# Altium schematic sheet ESP32_RC_MOD_V1.SchDoc
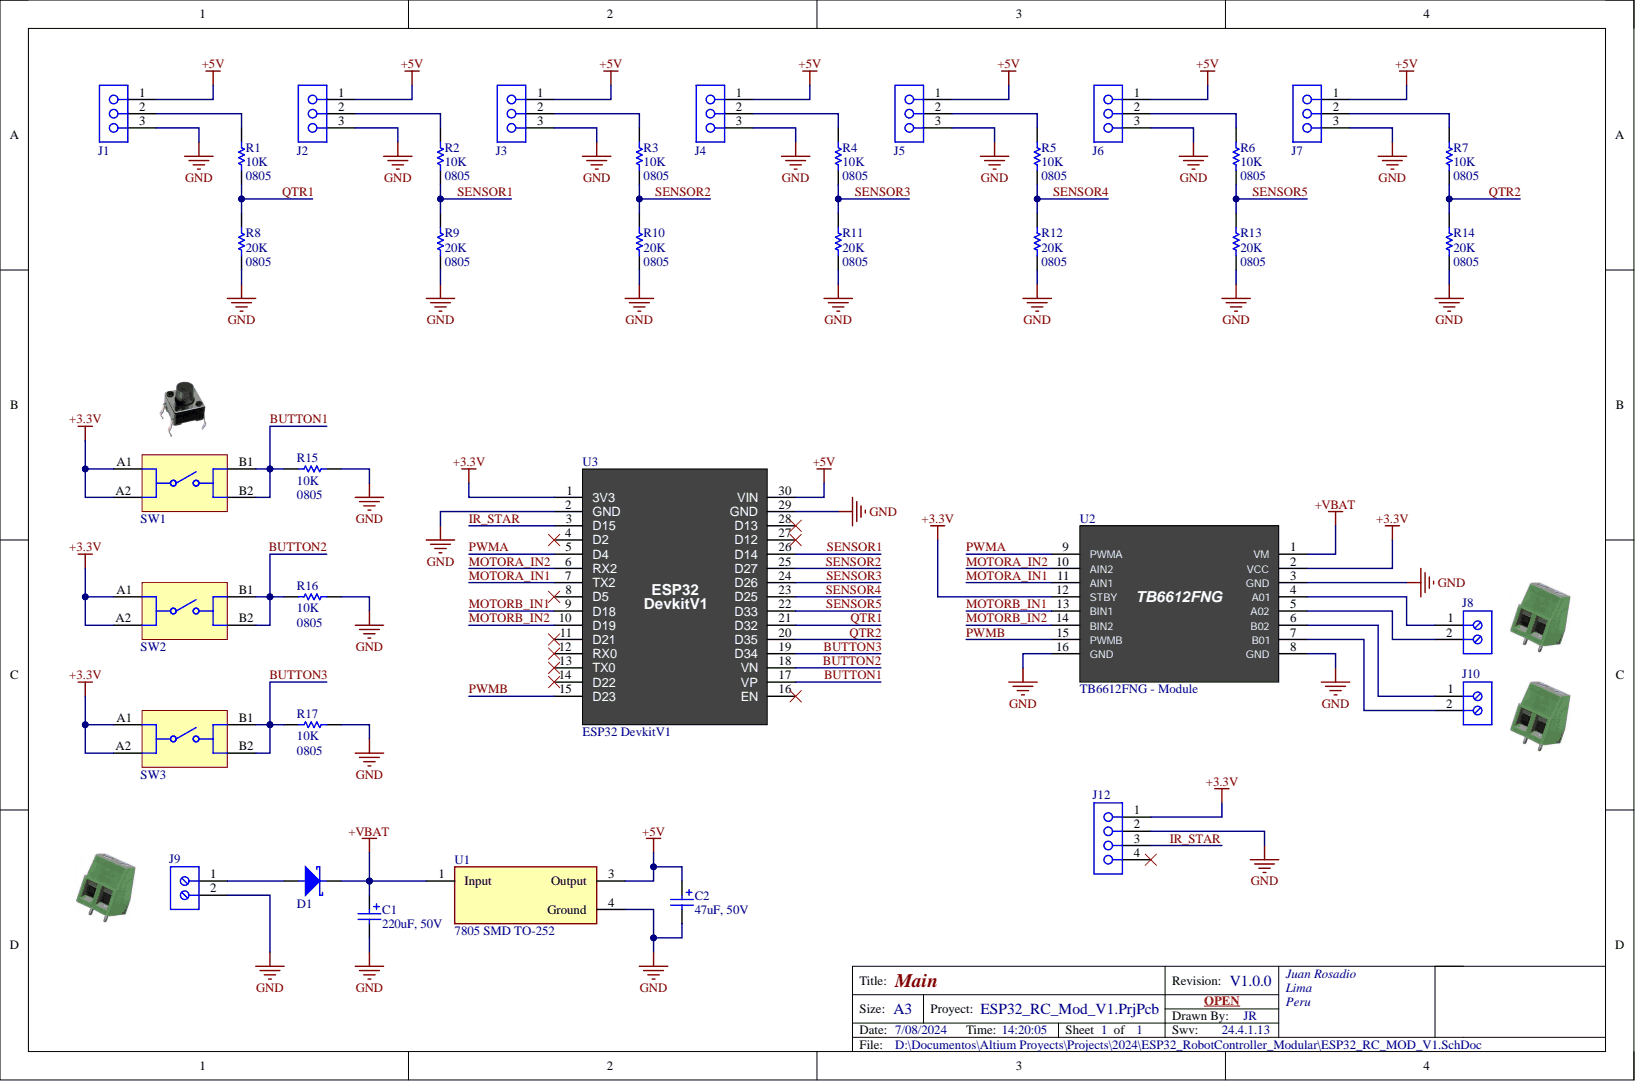
[diagram p0: ESP32_RC_MOD_V1.SchDoc]

## Parts
C1  220uF, 50V  [WCAP-ATG8_D10H12.5]
C2  47uF, 50V  [WCAP-ATG8_D6.3H11]
D1  Diode Schottky  [DO-214AB]  (value not drawn)
J1  Header 3P  [61300311821]  (value not drawn)
J2  Header 3P  [61300311821]  (value not drawn)
J3  Header 3P  [61300311821]  (value not drawn)
J4  Header 3P  [61300311821]  (value not drawn)
J5  Header 3P  [61300311821]  (value not drawn)
J6  Header 3P  [61300311821]  (value not drawn)
J7  Header 3P  [61300311821]  (value not drawn)
J8  Bornera de 2 pines  [691111710002]  (value not drawn)
J9  Bornera de 2 pines  [691111710002]  (value not drawn)
J10  Bornera de 2 pines  [691111710002]  (value not drawn)
J12  Header 4p  [61300411821]  (value not drawn)
R1  Case/Package: 0805  Resistance: 10K  [RES0805A]  (hidden comment: Resistor 0805)
R2  Case/Package: 0805  Resistance: 10K  [RES0805A]  (hidden comment: Resistor 0805)
R3  Case/Package: 0805  Resistance: 10K  [RES0805A]  (hidden comment: Resistor 0805)
R4  Case/Package: 0805  Resistance: 10K  [RES0805A]  (hidden comment: Resistor 0805)
R5  Case/Package: 0805  Resistance: 10K  [RES0805A]  (hidden comment: Resistor 0805)
R6  Case/Package: 0805  Resistance: 10K  [RES0805A]  (hidden comment: Resistor 0805)
R7  Case/Package: 0805  Resistance: 10K  [RES0805A]  (hidden comment: Resistor 0805)
R8  Case/Package: 0805  Resistance: 20K  [RES0805A]  (hidden comment: Resistor 0805)
R9  Case/Package: 0805  Resistance: 20K  [RES0805A]  (hidden comment: Resistor 0805)
R10  Case/Package: 0805  Resistance: 20K  [RES0805A]  (hidden comment: Resistor 0805)
R11  Case/Package: 0805  Resistance: 20K  [RES0805A]  (hidden comment: Resistor 0805)
R12  Case/Package: 0805  Resistance: 20K  [RES0805A]  (hidden comment: Resistor 0805)
R13  Case/Package: 0805  Resistance: 20K  [RES0805A]  (hidden comment: Resistor 0805)
R14  Case/Package: 0805  Resistance: 20K  [RES0805A]  (hidden comment: Resistor 0805)
R15  Case/Package: 0805  Resistance: 10K  [RES0805A]  (hidden comment: Resistor 0805)
R16  Case/Package: 0805  Resistance: 10K  [RES0805A]  (hidden comment: Resistor 0805)
R17  Case/Package: 0805  Resistance: 10K  [RES0805A]  (hidden comment: Resistor 0805)
SW1  XUNPU TS-1002S-07026C  [4301x60707x6]  (value not drawn)
SW2  XUNPU TS-1002S-07026C  [4301x60707x6]  (value not drawn)
SW3  XUNPU TS-1002S-07026C  [4301x60707x6]  (value not drawn)
U1  7805 SMD TO-252  [FP-369C-MFG]
U2  TB6612FNG - Module  [TB6612FNG-HDR-3D]
U3  ESP32 DevkitV1  [ESP32 DEVKITV1 - HDR - 3D]

## Nets
+3.3V: J12.1 SW1.A1 SW1.A2 SW2.A1 SW2.A2 SW3.A1 SW3.A2 U2.2(VCC) U2.12(STBY) U3.1(3V3)
+5V: C2.1 J1.1 J2.1 J3.1 J4.1 J5.1 J6.1 J7.1 U1.3 U3.30(VIN)
+VBAT: C1.1 D1.2 U1.1 U2.1(VM)
GND: C1.2 C2.2 J1.3 J2.3 J3.3 J4.3 J5.3 J6.3 J7.3 J9.2 J12.2 R8.1 R9.1 R10.1 R11.1 R12.1 R13.1 R14.1 R15.1 R16.1 R17.1 U1.4 U2.3(GND) U2.8(GND) U2.16(GND) U3.2(GND) U3.29(GND)
BUTTON1: R15.2 SW1.B1 SW1.B2 U3.17(VP)
BUTTON2: R16.2 SW2.B1 SW2.B2 U3.18(VN)
BUTTON3: R17.2 SW3.B1 SW3.B2 U3.19(D34)
IR_STAR: J12.3 U3.3(D15)
MOTORA_IN1: U2.11(AIN1) U3.7(TX2)
MOTORA_IN2: U2.10(AIN2) U3.6(RX2)
MOTORB_IN1: U2.13(BIN1) U3.9(D18)
MOTORB_IN2: U2.14(BIN2) U3.10(D19)
PWMA: U2.9(PWMA) U3.5(D4)
PWMB: U2.15(PWMB) U3.15(D23)
QTR1: R1.1 R8.2 U3.21(D32)
QTR2: R7.1 R14.2 U3.20(D35)
SENSOR1: R2.1 R9.2 U3.26(D14)
SENSOR2: R3.1 R10.2 U3.25(D27)
SENSOR3: R4.1 R11.2 U3.24(D26)
SENSOR4: R5.1 R12.2 U3.23(D25)
SENSOR5: R6.1 R13.2 U3.22(D33)
NetD1_1: D1.1 J9.1
NetJ1_2: J1.2 R1.2
NetJ2_2: J2.2 R2.2
NetJ3_2: J3.2 R3.2
NetJ4_2: J4.2 R4.2
NetJ5_2: J5.2 R5.2
NetJ6_2: J6.2 R6.2
NetJ7_2: J7.2 R7.2
NetJ8_1: J8.1 U2.4(A01)
NetJ8_2: J8.2 U2.5(A02)
NetJ10_1: J10.1 U2.6(B02)
NetJ10_2: J10.2 U2.7(B01)

Not connected: J12 4; U3 4(D2) 8(D5) 11(D21) 12(RX0) 13(TX0) 14(D22) 16(EN) 27(D12) 28(D13)

## Library data of the parts
C1 = 860010675018: WCAP-ATG8 Aluminum Electrolytic Capacitor, Radial, THT, D10xH12.5, 220uF, 50V; Manufacturer: Wurth Elektronik; Capacitance Tolerance: +/-20%; Dissipation Factor: 10%; Endurance: 2000h; Leakage Current: 110uA; Rated Voltage: 50VDC; Ripple Current: 468mA; Technical Reference: ATBF125221M050DSPA9B000; Capacitance: 220uF; Component Kind: Standard; ComponentLink1Description: Manufacturer; ComponentLink1URL: http://www.we-online.de; ComponentLink2Description: Datasheet; ComponentLink2URL: https://www.we-online.com/catalog/datasheet/860010675018.pdf; Diameter: 10mm; Height: 12.5mm; Manufacturer Part Number: 860010675018; Mounting Technology: THT; Operating Temperature: -40 to +85degC; Packaging: Ammo Pack; Published Date: October 2020; Library Name: WCAP-ATG8 Aluminion Electrolytic Capacitors.IntLib
C2 = 860010673012: WCAP-ATG8 Aluminum Electrolytic Capacitor, Radial, THT, D6.3xH11, 47uF, 50V; Manufacturer: Wurth Elektronik; Capacitance Tolerance: +/-20%; Dissipation Factor: 10%; Endurance: 2000h; Leakage Current: 23.5uA; Rated Voltage: 50VDC; Ripple Current: 165mA; Technical Reference: ATBD110470M050DSPA4B000; Capacitance: 47uF; Component Kind: Standard; ComponentLink1Description: Manufacturer; ComponentLink1URL: http://www.we-online.de; ComponentLink2Description: Datasheet; ComponentLink2URL: https://www.we-online.com/catalog/datasheet/860010673012.pdf; Diameter: 6.3mm; Height: 11mm; Manufacturer Part Number: 860010673012; Mounting Technology: THT; Operating Temperature: -40 to +85degC; Packaging: Ammo Pack; Published Date: October 2020; Library Name: WCAP-ATG8 Aluminion Electrolytic Capacitors.IntLib
D1 = Diode Schottky: Diodo Schottky SS36 3A 60 V; Forward Current: 4A; Voltage Rating (DC): 40V; Category: Rectifier Diodes; Component Kind: Standard; Library Name: Diodos.IntLib
J1-J7 = 61300311821: WR-PHD Socket Header, THT, Vertical, pitch 2.54mm, 1 Row, 3P; Manufacturer: Wurth Elektronik; Contact Resistance: 20mOhm; Rated Current: 3A; Withstanding Voltage: 500V; Working Voltage: 250V; Category: Board-to-Board Connectors; Component Kind: Standard; ComponentLink1Description: Website Link; ComponentLink1URL: https://www.we-online.com/redexpert/article/61300311821?ad; ComponentLink2Description: Datasheet Link; ComponentLink2URL: https://www.we-online.com/redexpert/spec/61300311821?ad; Gender: Socket Header; Library Name: WR-PHD (rev24a).IntLib; Manufacturer Part Number: 61300311821; Match Code: WR-PHD; Mount: Through Hole; Number of Pins: 3; Number of Rows: 1; Operating Temperature Max: 125°C; Operating Temperature Min: -40°C; Orientation: Vertical; Packaging: Tray; Pitch: 2.54mm
J8-J10 = 691111710002: WR-TBL Serie 111 Horizontal Entry Modular, Pressure Clamp, THT, pitch 5mm, 2p; Manufacturer: Wurth Elektronik; Modularity: Yes; PCB/Cable/Panel: PCB; Pin Number: 2; Rated Current [UL]: 15A; Working Voltage [UL]: 250VAC; Application: Pressure Clamp; Component Kind: Standard; ComponentLink1Description: Manufacturer; ComponentLink1URL: http://www.we-online.de; ComponentLink2Description: Datasheet; ComponentLink2URL: https://katalog.we-online.de/em/datasheet/691111710002.pdf; Manufacturer Part Number: 691111710002; Mounting Technology: THT; Operating Temperature: -40 to +105degC; Packaging: Box; Pitch: 5mm; Published Date: Feburary 2021; Type: Horizontal; Library Name: WR-TBL Terminal Blocks.IntLib
J12 = 61300411821: WR-PHD Socket Header, THT, pitch 2.54mm, Single Row, Vertical, 4p; Manufacturer: Wurth Elektronik; Contact Resistance: 20mOhm; Pin Number: 4; Rated Current: 3A; Withstanding Voltage: 500VAC; Working Voltage: 250VAC; Component Kind: Standard; ComponentLink1Description: Manufacturer; ComponentLink1URL: http://www.we-online.de; ComponentLink2Description: Datasheet; ComponentLink2URL: https://www.we-online.com/catalog/datasheet/61300411821.pdf; Gender: Socket Header; Manufacturer Part Number: 61300411821; Mounting Technology: THT; Operating Temperature: -40 to +125degC; Orientation: Vertical; Packaging: Tray; Pitch: 2.54mm; Published Date: May 2020; Row Number: Single; Library Name: WE-WR PHD Conectors.IntLib
R1-R7 R15-R17 = Resistor 0805: SMD 0805 10Kohms; Power Rating: 125mW; Tolerance: 1%; Case Code (Metric): 2012; Category: Chip SMD Resistors; Component Kind: Standard; Mount: Surface Mount; Library Name: Resistencias SMD.IntLib
R8-R14 = Resistor 0805: SMD 0805 20Kohms; Power Rating: 125mW; Tolerance: 1%; Case Code (Metric): 2012; Category: Chip SMD Resistors; Component Kind: Standard; Mount: Surface Mount; Library Name: Resistencias SMD.IntLib
SW1-SW3 = 430156070726: 6mm 7mm Round Button 50mA Brick nogging 6mm SPST 12V SMD,6x6mm Tactile Switches ROHS; Circuit: SPST-NO; Match Code: WS-TATV; Operation Force: 260g; Rated Voltage: 12VDC; Washable: No; Category: Tact Switches; Component Kind: Standard; ComponentLink1Description: Website Link; ComponentLink1URL: https://www.we-online.com/redexpert/article/430156070726?ad; ComponentLink2Description: Datasheet Link; ComponentLink2URL: https://www.we-online.com/redexpert/spec/430156070726?ad; Contact Material: Stainless Steel; Contact Plating: Silver; Height: 7mm; Length: 6mm; Library Name: WS-TATV (rev22a).IntLib; Manufacturer: XUNPU; Manufacturer Part Number: TS-1002S-07026C; Mount: Through Hole; Operating Temperature Max: 85°C; Operating Temperature Min: -40°C; Packaging: Bulk; Rated Current: 50mA; Supplier: LCSC; Supplier Part Number: C455114; Terminal Material: Copper Alloy; Terminal Plating: Silver; Width: 6mm
U1 = CMP-07128-000005-1: IC REG LINEAR 5V 1A DPAK; Manufacturer: ON Semiconductor; Manufacturer Part Number: MC7805CDTG; Dropout Voltage: 2V; Max Junction Temp: +125°C; Max Supply Voltage: 35V; Min Supply Voltage: 7V; Nominal Supply Current: 3.2mA; Number Of Outputs: 1; Output Type: Fixed; Standoff Height: 0mm; Automotive: No; Category: IC; Component Kind: Standard; ComponentLink2Description: Datasheet; ComponentLink2URL: https://www.onsemi.cn/PowerSolutions/document/MC7800-D.PDF; Device Class L1: Integrated Circuits (ICs); Device Class L2: Power Management ICs; Device Class L3: Voltage Regulators - Linear; Digikey Description: IC REG LINEAR 5V 1A DPAK; Footprint Url: https://www.onsemi.com/pub/Collateral/369C.PDF; Height: 2.51mm; Lead Free: Yes; Library Name: Altium Content Vault; Mouser Description: Linear Voltage Regulator, Positive, 5.0 V, 1.0 A, V out : 5.0 V; T J = 0Â°C to +125Â°C; Octopart: https://octopart.com/mc7805cdtg-on+semiconductor-1266408; Output Current: 1A; Output Voltage: 5V; Package: 369C; RoHS: TRUE; Temperature: 0°C to +125°C; Temperature Range High: +125°C; Temperature Range Low: 0°C
U2 = TB6612FNG - Module: Driver Puente H TB6612FNG 1.2A; Component Kind: Standard; Library Name: Modulos.IntLib
U3 = ESP32 DevkitV1: ESP32 DEVKIT V1 Wireless WiFi Bluetooth Placa de desarrollo Micro USB Dual Core; Component Kind: Standard; Library Name: Modulos.IntLib
